# Altium schematic sheet 6_POWER1.SchDoc(6_POWER1)
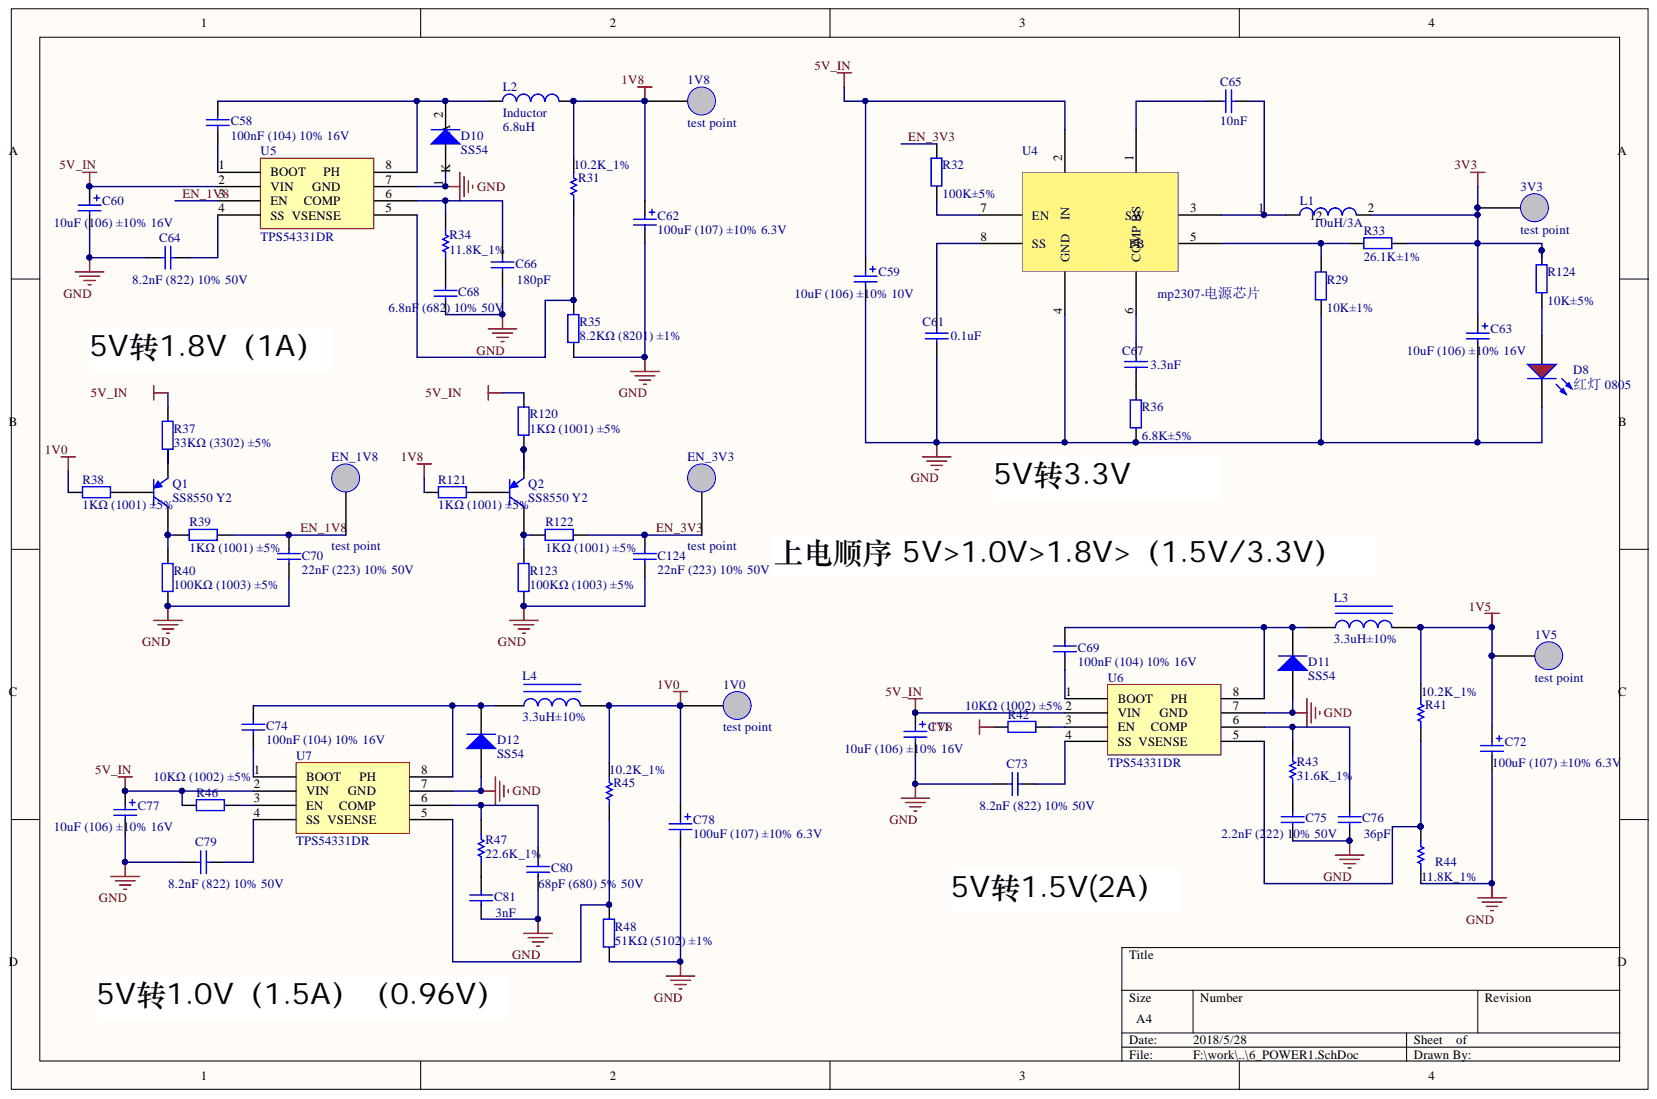
[diagram p0: 6_POWER1.SchDoc]

## Parts
1V0  test point  [TP_30mil]
1V5  test point  [TP_30mil]
1V8  test point  [TP_30mil]
3V3  test point  [TP_30mil]
C58  100nF (104) 10% 16V  [0402_C]
C59  10uF (106) ±10% 10V  [CASE-A_3216]
C60  10uF (106) ±10% 16V  [CASE-A_3216]
C61  value 0.1uF  [0603_C]  (hidden comment: Cap)
C62  100uF (107) ±10% 6.3V  [CASE-B_3528]
C63  10uF (106) ±10% 16V  [CASE-A_3216]
C64  8.2nF (822) 10% 50V  [0603_C]
C65  value 10nF  [0603_C]  (hidden comment: Cap)
C66  value 180pF  [0402_C]  (hidden comment: Cap Semi)
C67  value 3.3nF  [0603_C]  (hidden comment: Cap)
C68  6.8nF (682) 10% 50V  [0402_C]
C69  100nF (104) 10% 16V  [0402_C]
C70  22nF (223) 10% 50V  [0402_C]
C71  10uF (106) ±10% 16V  [CASE-A_3216]
C72  100uF (107) ±10% 6.3V  [CASE-B_3528]
C73  8.2nF (822) 10% 50V  [0603_C]
C74  100nF (104) 10% 16V  [0402_C]
C75  2.2nF (222) 10% 50V  [0402_C]
C76  value 36pF  [0402_C]  (hidden comment: Cap Semi)
C77  10uF (106) ±10% 16V  [CASE-A_3216]
C78  100uF (107) ±10% 6.3V  [CASE-B_3528]
C79  8.2nF (822) 10% 50V  [0603_C]
C80  68pF (680) 5% 50V  [0402_C]
C81  value 3nF  [0402_C]  (hidden comment: Cap Semi)
C124  22nF (223) 10% 50V  [0402_C]
D8  红灯 0805  [0805_LED_S1]
D10  SS54  [SMA(DO-214AC)_S1]
D11  SS54  [SMA(DO-214AC)_S1]
D12  SS54  [SMA(DO-214AC)_S1]
EN_1V8  test point  [TP_30mil]
EN_3V3  test point  [TP_30mil]
L1  Inductor  value 10uH/3A  [CD73]
L2  Inductor  value 6.8uH  [0805_L]
L3  3.3uH±10%  [CD73]
L4  3.3uH±10%  [CD73]
Q1  SS8550 Y2  [SOT-23(SOT-23-3)]
Q2  SS8550 Y2  [SOT-23(SOT-23-3)]
R29  value 10K±1%  [0603_R]  (hidden comment: Res2)
R31  value 10.2K_1%  [0603_R]  (hidden comment: Res1)
R32  value 100K±5%  [0603_R]  (hidden comment: Res2)
R33  value 26.1K±1%  [0603_R]  (hidden comment: Res2)
R34  11.8K_1%  [0603_R]
R35  8.2KΩ (8201) ±1%  [0805_R]
R36  value 6.8K±5%  [0603_R]  (hidden comment: Res2)
R37  33KΩ (3302) ±5%  [0402_R]
R38  1KΩ (1001) ±5%  [0402_R]
R39  1KΩ (1001) ±5%  [0402_R]
R40  100KΩ (1003) ±5%  [0402_R]
R41  value 10.2K_1%  [0603_R]  (hidden comment: Res1)
R42  10KΩ (1002) ±5%  [0402_R]
R43  31.6K_1%  [0603_R]
R44  value 11.8K_1%  [0805_R]  (hidden comment: Res1)
R45  value 10.2K_1%  [0603_R]  (hidden comment: Res1)
R46  10KΩ (1002) ±5%  [0402_R]
R47  22.6K_1%  [0603_R]
R48  51KΩ (5102) ±1%  [0805_R]
R120  1KΩ (1001) ±5%  [0402_R]
R121  1KΩ (1001) ±5%  [0402_R]
R122  1KΩ (1001) ±5%  [0402_R]
R123  100KΩ (1003) ±5%  [0402_R]
R124  value 10K±5%  [0603_R]  (hidden comment: Res2)
U4  mp2307-电源芯片  [SOIC-8_150mil]
U5  TPS54331DR  [SOIC-8_150mil]
U6  TPS54331DR  [SOIC-8_150mil]
U7  TPS54331DR  [SOIC-8_150mil]

## Nets
1V0: 1V0.1 C78.1 L4.2 R38.1 R45.2
1V5: 1V5.1 C72.1 L3.2 R41.2
1V8: 1V8.1 C62.1 L2.2 R31.2 R42.1 R121.1
3V3: 3V3.1 C63.1 L1.2 R33.1 R124.2
5V_IN: C59.1 C60.1 C71.1 C77.1 R37.2 R46.1 R120.2 U4.2 U5.2(VIN) U6.2(VIN) U7.2(VIN)
GND: C59.2 C60.2 C61.1 C62.2 C63.2 C64.1 C66.1 C68.1 C70.1 C71.2 C72.2 C73.1 C75.1 C76.1 C77.2 C78.2 C79.1 C80.1 C81.1 C124.1 D8.K D10.1 D11.1 D12.1 R29.1 R35.1 R36.1 R40.1 R44.1 R48.1 R123.1 U4.4(GND) U5.7 U6.7 U7.7
EN_1V8: C70.2 EN_1V8.1 R39.2 U5.3(EN)
EN_3V3: C124.2 EN_3V3.1 R32.2 R122.2
NetC58_1: C58.1 U5.1(BOOT)
NetC58_2: C58.2 D10.2 L2.1 U5.8
NetC61_2: C61.2 U4.8(SS)
NetC64_2: C64.2 U5.4(SS)
NetC65_1: C65.1 L1.1 U4.3
NetC65_2: C65.2 U4.1
NetC66_2: C66.2 R34.2 U5.6
NetC67_1: C67.1 R36.2
NetC67_2: C67.2 U4.6(COMP)
NetC68_2: C68.2 R34.1
NetC69_1: C69.1 U6.1(BOOT)
NetC69_2: C69.2 D11.2 L3.1 U6.8
NetC73_2: C73.2 U6.4(SS)
NetC74_1: C74.1 U7.1(BOOT)
NetC74_2: C74.2 D12.2 L4.1 U7.8
NetC75_2: C75.2 R43.1
NetC76_2: C76.2 R43.2 U6.6
NetC79_2: C79.2 U7.4(SS)
NetC80_2: C80.2 R47.2 U7.6
NetC81_2: C81.2 R47.1
NetD8_A: D8.A R124.1
NetQ1_1: Q1.1 R38.2
NetQ1_2: Q1.2 R37.1
NetQ1_3: Q1.3 R39.1 R40.2
NetQ2_1: Q2.1 R121.2
NetQ2_2: Q2.2 R120.1
NetQ2_3: Q2.3 R122.1 R123.2
NetR29_2: R29.2 R33.2 U4.5
NetR31_1: R31.1 R35.2 U5.5
NetR32_1: R32.1 U4.7(EN)
NetR41_1: R41.1 R44.2 U6.5
NetR42_2: R42.2 U6.3(EN)
NetR45_1: R45.1 R48.2 U7.5
NetR46_2: R46.2 U7.3(EN)

## Library data of the parts
1V0 1V5 1V8 3V3 EN_1V8 EN_3V3 = TP: Component Kind: Standard; Library Name: Personal_Accumulation_Sch.SchLib
C58 C69 C74 = 0402 100nF (104) 10% 16V: 贴片电容; Component Kind: Standard; ComponentLink1Description: 供应商链接; ComponentLink1URL: http://www.szlcsc.com/product/details_1877.html; Library Name: Miscellaneous Devices LC.IntLib; Package: 0402; Supplier: LC; SuppliersPartNumber: C1525
C59 C60 C63 C71 C77 = 3216 10uF (106) 10% 16V: 钽电容; Component Kind: Standard; ComponentLink1Description: 供应商链接; ComponentLink1URL: http://www.szlcsc.com/product/details_7643.html; Library Name: Miscellaneous Devices LC.IntLib; Package: 3216; Supplier: LC; SuppliersPartNumber: C7171
C61 C65 C67 = Cap: Capacitor; Component Kind: Standard; LatestRevisionDate: 17-Jul-2002; LatestRevisionNote: Re-released for DXP Platform.; Library Name: Miscellaneous Devices.IntLib; PackageDescription: Radial Cap, Thru-Hole; 2 Leads; 0.3 in Pin Spacing; PackageReference: RAD-0.3; Published: 8-Jun-2000; Signal Integrity: Cap; Simulation: CAP; Publisher: Altium Limited
C62 C72 C78 = 3528 100uF (107) 10% 6.3V: 钽电容; Component Kind: Standard; ComponentLink1Description: 供应商链接; ComponentLink1URL: http://www.szlcsc.com/product/details_16815.html; Library Name: Miscellaneous Devices LC.IntLib; Package: 3528; Supplier: LC; SuppliersPartNumber: C16133
C64 C73 C79 = 0603 8.2nF (822) 10% 50V: 贴片电容; Component Kind: Standard; ComponentLink1Description: 供应商链接; ComponentLink1URL: http://www.szlcsc.com/product/details_28670.html; Library Name: Miscellaneous Devices LC.IntLib; Package: 0603; Supplier: LC; SuppliersPartNumber: C27920
C66 C76 C81 = Cap Semi: Capacitor (Semiconductor SIM Model); Component Kind: Standard; LatestRevisionDate: 29-May-2009; LatestRevisionNote: IPC-7351 Footprint Added.; Library Name: Miscellaneous Devices.IntLib; PackageDescription: Chip Capacitor; PackageReference: C1206; Published: 8-Jun-2000; Signal Integrity: Cap Semi; Simulation: CAP; Publisher: Altium Limited
C68 = 0402 6.8nF (682) 10% 50V: 贴片电容; Component Kind: Standard; ComponentLink1Description: 供应商链接; ComponentLink1URL: http://www.szlcsc.com/product/details_1894.html; Library Name: Miscellaneous Devices LC.IntLib; Package: 0402; Supplier: LC; SuppliersPartNumber: C1542
C70 C124 = 0402 22nF (223) 10% 50V: 贴片电容; Component Kind: Standard; ComponentLink1Description: 供应商链接; ComponentLink1URL: http://www.szlcsc.com/product/details_1884.html; Library Name: Miscellaneous Devices LC.IntLib; Package: 0402; Supplier: LC; SuppliersPartNumber: C1532
C75 = 0402 2.2nF (222) 10% 50V: 贴片电容; Component Kind: Standard; ComponentLink1Description: 供应商链接; ComponentLink1URL: http://www.szlcsc.com/product/details_1883.html; Library Name: Miscellaneous Devices LC.IntLib; Package: 0402; Supplier: LC; SuppliersPartNumber: C1531
C80 = 0402 68pF (680) 5% 50V: 贴片电容; Component Kind: Standard; ComponentLink1Description: 供应商链接; ComponentLink1URL: http://www.szlcsc.com/product/details_15103.html; Library Name: Miscellaneous Devices LC.IntLib; Package: 0402; Supplier: LC; SuppliersPartNumber: C14441
D8 = 0805 红灯: 发光二极管; Component Kind: Standard; ComponentLink1Description: 供应商链接; ComponentLink1URL: http://www.szlcsc.com/product/details_2668.html; Library Name: Miscellaneous Devices LC.IntLib; Package: 0805; Supplier: LC; SuppliersPartNumber: C2295
D10-D12 = SMA(DO-214AC) SS54: 二极管; Component Kind: Standard; ComponentLink1Description: 供应商链接; ComponentLink1URL: http://www.szlcsc.com/product/details_23178.html; Library Name: Miscellaneous Devices LC.IntLib; Package: SMA(DO-214AC); Supplier: LC; SuppliersPartNumber: C22452
L1 L2 = Inductor: Code_IEC: 1005; Code_JEITA: 0402; Component Kind: Standard; Description: Inductor; LatestRevisionDate: 29-May-2009; LatestRevisionNote: IPC-7351 Footprint Added.; Library Name: Miscellaneous Devices.IntLib; PackageDescription: Chip Inductor; PackageReference: 0402-A; Published: 8-Jun-2000; Signal Integrity: Inductor; Simulation: INDUCTOR; Publisher: Altium Limited
L3 L4 = 0805 3.3uH10%: 贴片电感; Component Kind: Standard; ComponentLink1Description: 供应商链接; ComponentLink1URL: http://www.szlcsc.com/product/details_52738.html; Library Name: Miscellaneous Devices LC.IntLib; Package: 0805; Supplier: LC; SuppliersPartNumber: C51725
Q1 Q2 = SOT-23 SS8550 Y2: 三极管; Component Kind: Standard; ComponentLink1Description: 供应商链接; ComponentLink1URL: http://www.szlcsc.com/product/details_9037.html; Library Name: Miscellaneous Devices LC.IntLib; Package: SOT-23; Supplier: LC; SuppliersPartNumber: C8542
R29 R32 R33 R36 R124 = Res2: Resistor; Component Kind: Standard; LatestRevisionDate: 17-Jul-2002; LatestRevisionNote: Re-released for DXP Platform.; Library Name: Miscellaneous Devices.IntLib; PackageDescription: Axial Device, Thru-Hole; 2 Leads; 0.4 in Pin Spacing; PackageReference: AXIAL-0.4; Published: 8-Jun-2000; Signal Integrity: Res; Simulation: RESISTOR; Publisher: Altium Limited
R31 R34 R41 R43-R45 R47 = Res1: Resistor; Component Kind: Standard; LatestRevisionDate: 17-Jul-2002; LatestRevisionNote: Re-released for DXP Platform.; Library Name: Miscellaneous Devices.IntLib; PackageDescription: Axial Device, Thru-Hole; 2 Leads; 0.3 in Pin Spacing; PackageReference: AXIAL-0.3; Published: 8-Jun-2000; Signal Integrity: Res; Simulation: RESISTOR; Publisher: Altium Limited
  R34: Value: 11.8K_1%
  R43: Value: 31.6K_1%
  R47: Value: 22.6K_1%
R35 = 0805 8.2KΩ (8201) 1%: 贴片电阻; Component Kind: Standard; ComponentLink1Description: 供应商链接; ComponentLink1URL: http://www.szlcsc.com/product/details_18516.html; Library Name: Miscellaneous Devices LC.IntLib; Package: 0805; Supplier: LC; SuppliersPartNumber: C17828
R37 = 0402 33KΩ (3302) 1%: 贴片电阻; Component Kind: Standard; ComponentLink1Description: 供应商链接; ComponentLink1URL: http://www.szlcsc.com/product/details_26522.html; Library Name: Miscellaneous Devices LC.IntLib; Package: 0402; Supplier: LC; SuppliersPartNumber: C25779
R38 R39 R120-R122 = 0402 1KΩ (1001) 1%: 贴片电阻; Component Kind: Standard; ComponentLink1Description: 供应商链接; ComponentLink1URL: http://www.szlcsc.com/product/details_12256.html; Library Name: Miscellaneous Devices LC.IntLib; Package: 0402; Supplier: LC; SuppliersPartNumber: C11702
R40 R123 = 0402 100KΩ (1003) 1%: 贴片电阻; Component Kind: Standard; ComponentLink1Description: 供应商链接; ComponentLink1URL: http://www.szlcsc.com/product/details_26484.html; Library Name: Miscellaneous Devices LC.IntLib; Package: 0402; Supplier: LC; SuppliersPartNumber: C25741
R42 R46 = 0402 10KΩ (1002) 1%: 贴片电阻; Component Kind: Standard; ComponentLink1Description: 供应商链接; ComponentLink1URL: http://www.szlcsc.com/product/details_26487.html; Library Name: Miscellaneous Devices LC.IntLib; Package: 0402; Supplier: LC; SuppliersPartNumber: C25744
R48 = 0805 51KΩ (5102) 1%: 贴片电阻; Component Kind: Standard; ComponentLink1Description: 供应商链接; ComponentLink1URL: http://www.szlcsc.com/product/details_18425.html; Library Name: Miscellaneous Devices LC.IntLib; Package: 0805; Supplier: LC; SuppliersPartNumber: C17737
U5-U7 = TPS54331DR: DC-DC芯片; Component Kind: Standard; ComponentLink1Description: 供应商链接; ComponentLink1URL: http://www.szlcsc.com/product/details_10397.html; Library Name: Miscellaneous Devices LC.IntLib; Package: SOIC-8_150mil; Supplier: LC; SuppliersPartNumber: C9865
U4 = mp2307-电源芯片: Component Kind: Standard; Library Name: MY_LIBRARIES.SchLib
